# Altium schematic sheet Sheet2.SchDoc(Sheet2)
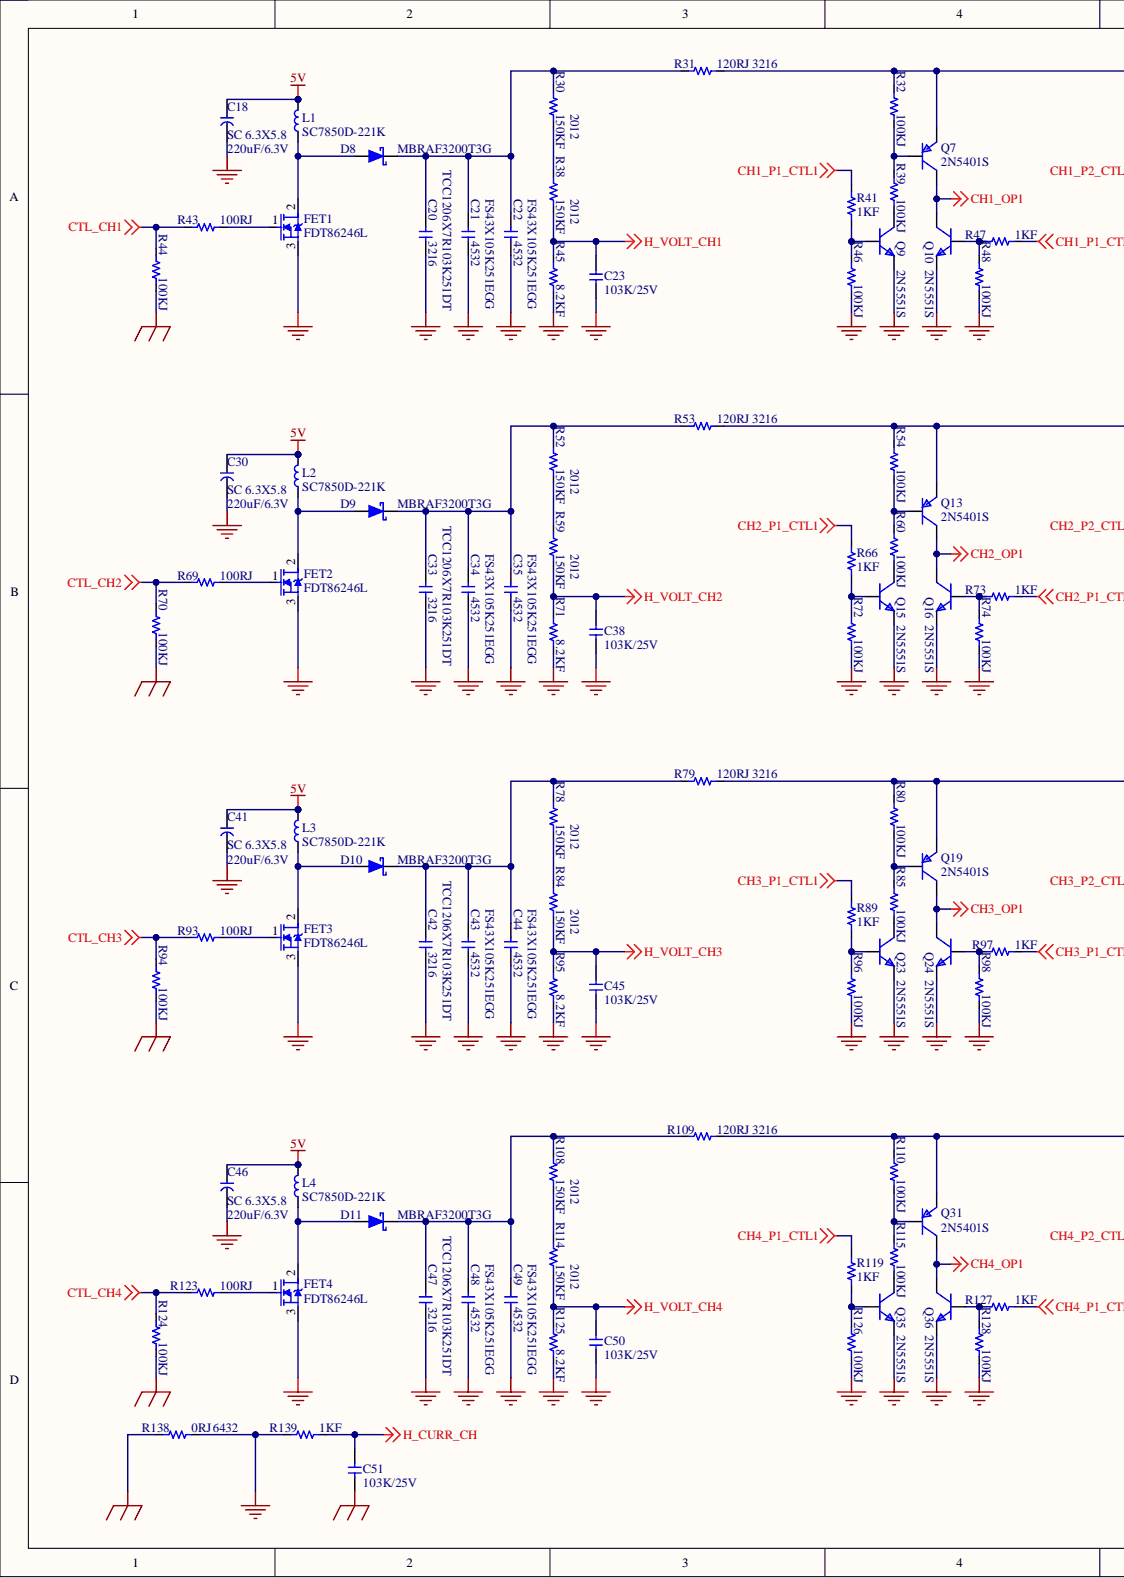
[diagram p0: Sheet2.SchDoc, left]
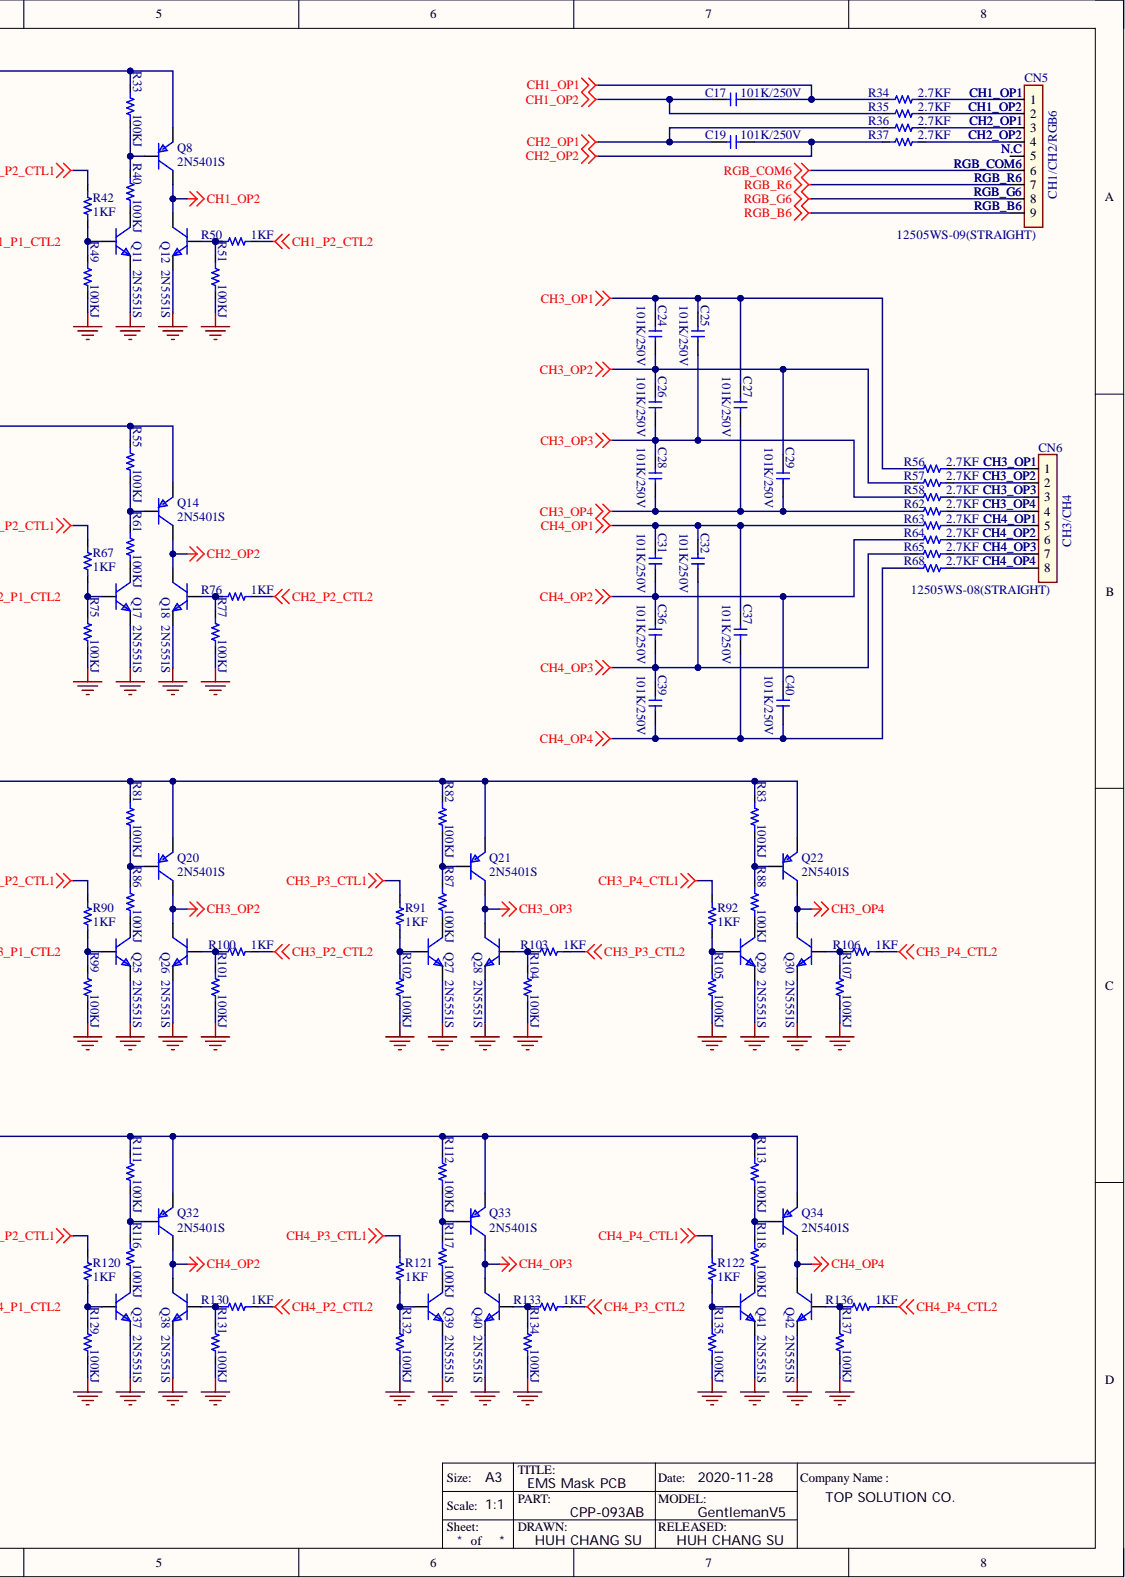
[diagram p1: Sheet2.SchDoc, right]

## Parts
C17  101K/250V  [SMD C 0805]
C18  220uF/6.3V  [SMD SC D(6.3*5.4)]
C19  101K/250V  [SMD C 0805]
C20  TCC1206X7R103K251DT  TYPE: 3216  [SMD C 1206]
C21  FS43X105K251EGG  TYPE: 4532  [SMD C 1812]
C22  FS43X105K251EGG  TYPE: 4532  [SMD C 1812]
C23  103K/25V  [SMD C 0603]
C24  101K/250V  [SMD C 0805]
C25  101K/250V  [SMD C 0805]
C26  101K/250V  [SMD C 0805]
C27  101K/250V  [SMD C 0805]
C28  101K/250V  [SMD C 0805]
C29  101K/250V  [SMD C 0805]
C30  220uF/6.3V  [SMD SC D(6.3*5.4)]
C31  101K/250V  [SMD C 0805]
C32  101K/250V  [SMD C 0805]
C33  TCC1206X7R103K251DT  TYPE: 3216  [SMD C 1206]
C34  FS43X105K251EGG  TYPE: 4532  [SMD C 1812]
C35  FS43X105K251EGG  TYPE: 4532  [SMD C 1812]
C36  101K/250V  [SMD C 0805]
C37  101K/250V  [SMD C 0805]
C38  103K/25V  [SMD C 0603]
C39  101K/250V  [SMD C 0805]
C40  101K/250V  [SMD C 0805]
C41  220uF/6.3V  [SMD SC D(6.3*5.4)]
C42  TCC1206X7R103K251DT  TYPE: 3216  [SMD C 1206]
C43  FS43X105K251EGG  TYPE: 4532  [SMD C 1812]
C44  FS43X105K251EGG  TYPE: 4532  [SMD C 1812]
C45  103K/25V  [SMD C 0603]
C46  220uF/6.3V  [SMD SC D(6.3*5.4)]
C47  TCC1206X7R103K251DT  TYPE: 3216  [SMD C 1206]
C48  FS43X105K251EGG  TYPE: 4532  [SMD C 1812]
C49  FS43X105K251EGG  TYPE: 4532  [SMD C 1812]
C50  103K/25V  [SMD C 0603]
C51  103K/25V  [SMD C 0603]
CN5  12505WS-09(STRAIGHT)  EXPLANATION: CH1/CH2/RGB6  [SMD 12505WS-09_L]
CN6  12505WS-08(STRAIGHT)  EXPLANATION: CH3/CH4  [SMD 12505WS-08_L]
D8  MBRAF3200T3G  [SD SMA]
D9  MBRAF3200T3G  [SD SMA]
D10  MBRAF3200T3G  [SD SMA]
D11  MBRAF3200T3G  [SD SMA]
FET1  FDT86246L  [SOT223_M]
FET2  FDT86246L  [SOT223_M]
FET3  FDT86246L  [SOT223_M]
FET4  FDT86246L  [SOT223_M]
L1  SC7850D-221K  [SMD CD75]
L2  SC7850D-221K  [SMD CD75]
L3  SC7850D-221K  [SMD CD75]
L4  SC7850D-221K  [SMD CD75]
Q7  2N5401S  [SOT23-3N]
Q8  2N5401S  [SOT23-3N]
Q9  2N5551S  [SOT23-3N]
Q10  2N5551S  [SOT23-3N]
Q11  2N5551S  [SOT23-3N]
Q12  2N5551S  [SOT23-3N]
Q13  2N5401S  [SOT23-3N]
Q14  2N5401S  [SOT23-3N]
Q15  2N5551S  [SOT23-3N]
Q16  2N5551S  [SOT23-3N]
Q17  2N5551S  [SOT23-3N]
Q18  2N5551S  [SOT23-3N]
Q19  2N5401S  [SOT23-3N]
Q20  2N5401S  [SOT23-3N]
Q21  2N5401S  [SOT23-3N]
Q22  2N5401S  [SOT23-3N]
Q23  2N5551S  [SOT23-3N]
Q24  2N5551S  [SOT23-3N]
Q25  2N5551S  [SOT23-3N]
Q26  2N5551S  [SOT23-3N]
Q27  2N5551S  [SOT23-3N]
Q28  2N5551S  [SOT23-3N]
Q29  2N5551S  [SOT23-3N]
Q30  2N5551S  [SOT23-3N]
Q31  2N5401S  [SOT23-3N]
Q32  2N5401S  [SOT23-3N]
Q33  2N5401S  [SOT23-3N]
Q34  2N5401S  [SOT23-3N]
Q35  2N5551S  [SOT23-3N]
Q36  2N5551S  [SOT23-3N]
Q37  2N5551S  [SOT23-3N]
Q38  2N5551S  [SOT23-3N]
Q39  2N5551S  [SOT23-3N]
Q40  2N5551S  [SOT23-3N]
Q41  2N5551S  [SOT23-3N]
Q42  2N5551S  [SOT23-3N]
R30  150KF  TYPE: 2012  [SR 0805]
R31  120RJ  TYPE: 3216  [SR 1206]
R32  100KJ  [SR 0603]
R33  100KJ  [SR 0603]
R34  2.7KF  [SR 0603]
R35  2.7KF  [SR 0603]
R36  2.7KF  [SR 0603]
R37  2.7KF  [SR 0603]
R38  150KF  TYPE: 2012  [SR 0805]
R39  100KJ  [SR 0603]
R40  100KJ  [SR 0603]
R41  1KF  [SR 0603]
R42  1KF  [SR 0603]
R43  100RJ  [SR 0603]
R44  100KJ  [SR 0603]
R45  8.2KF  [SR 0603]
R46  100KJ  [SR 0603]
R47  1KF  [SR 0603]
R48  100KJ  [SR 0603]
R49  100KJ  [SR 0603]
R50  1KF  [SR 0603]
R51  100KJ  [SR 0603]
R52  150KF  TYPE: 2012  [SR 0805]
R53  120RJ  TYPE: 3216  [SR 1206]
R54  100KJ  [SR 0603]
R55  100KJ  [SR 0603]
R56  2.7KF  [SR 0603]
R57  2.7KF  [SR 0603]
R58  2.7KF  [SR 0603]
R59  150KF  TYPE: 2012  [SR 0805]
R60  100KJ  [SR 0603]
R61  100KJ  [SR 0603]
R62  2.7KF  [SR 0603]
R63  2.7KF  [SR 0603]
R64  2.7KF  [SR 0603]
R65  2.7KF  [SR 0603]
R66  1KF  [SR 0603]
R67  1KF  [SR 0603]
R68  2.7KF  [SR 0603]
R69  100RJ  [SR 0603]
R70  100KJ  [SR 0603]
R71  8.2KF  [SR 0603]
R72  100KJ  [SR 0603]
R73  1KF  [SR 0603]
R74  100KJ  [SR 0603]
R75  100KJ  [SR 0603]
R76  1KF  [SR 0603]
R77  100KJ  [SR 0603]
R78  150KF  TYPE: 2012  [SR 0805]
R79  120RJ  TYPE: 3216  [SR 1206]
R80  100KJ  [SR 0603]
R81  100KJ  [SR 0603]
R82  100KJ  [SR 0603]
R83  100KJ  [SR 0603]
R84  150KF  TYPE: 2012  [SR 0805]
R85  100KJ  [SR 0603]
R86  100KJ  [SR 0603]
R87  100KJ  [SR 0603]
R88  100KJ  [SR 0603]
R89  1KF  [SR 0603]
R90  1KF  [SR 0603]
R91  1KF  [SR 0603]
R92  1KF  [SR 0603]
R93  100RJ  [SR 0603]
R94  100KJ  [SR 0603]
R95  8.2KF  [SR 0603]
R96  100KJ  [SR 0603]
R97  1KF  [SR 0603]
R98  100KJ  [SR 0603]
R99  100KJ  [SR 0603]
R100  1KF  [SR 0603]
R101  100KJ  [SR 0603]
R102  100KJ  [SR 0603]
R103  1KF  [SR 0603]
R104  100KJ  [SR 0603]
R105  100KJ  [SR 0603]
R106  1KF  [SR 0603]
R107  100KJ  [SR 0603]
R108  150KF  TYPE: 2012  [SR 0805]
R109  120RJ  TYPE: 3216  [SR 1206]
R110  100KJ  [SR 0603]
R111  100KJ  [SR 0603]
R112  100KJ  [SR 0603]
R113  100KJ  [SR 0603]
R114  150KF  TYPE: 2012  [SR 0805]
R115  100KJ  [SR 0603]
R116  100KJ  [SR 0603]
R117  100KJ  [SR 0603]
R118  100KJ  [SR 0603]
R119  1KF  [SR 0603]
R120  1KF  [SR 0603]
R121  1KF  [SR 0603]
R122  1KF  [SR 0603]
R123  100RJ  [SR 0603]
R124  100KJ  [SR 0603]
R125  8.2KF  [SR 0603]
R126  100KJ  [SR 0603]
R127  1KF  [SR 0603]
R128  100KJ  [SR 0603]
R129  100KJ  [SR 0603]
R130  1KF  [SR 0603]
R131  100KJ  [SR 0603]
R132  100KJ  [SR 0603]
R133  1KF  [SR 0603]
R134  100KJ  [SR 0603]
R135  100KJ  [SR 0603]
R136  1KF  [SR 0603]
R137  100KJ  [SR 0603]
R138  0RJ  TYPE: 6432  [SR 2512]
R139  1KF  [SR 0603]

## Nets
5V: C18.1 C30.1 C41.1 C46.1 L1.2 L2.2 L3.2 L4.2
CH1_OP1: C17.2 Q7.3 Q10.3 R34.1
CH1_OP2: C17.1 Q8.3 Q12.3 R35.1
CH1_P1_CTL1: R41.2
CH1_P1_CTL2: R47.2
CH1_P2_CTL1: R42.2
CH1_P2_CTL2: R50.2
CH2_OP1: C19.1 Q13.3 Q16.3 R36.1
CH2_OP2: C19.2 Q14.3 Q18.3 R37.1
CH2_P1_CTL1: R66.2
CH2_P1_CTL2: R73.2
CH2_P2_CTL1: R67.2
CH2_P2_CTL2: R76.2
CH3_OP1: C24.2 C25.2 C27.2 Q19.3 Q24.3 R56.1
CH3_OP2: C24.1 C26.2 C29.2 Q20.3 Q26.3 R57.1
CH3_OP3: C25.1 C26.1 C28.2 Q21.3 Q28.3 R58.1
CH3_OP4: C27.1 C28.1 C29.1 Q22.3 Q30.3 R62.1
CH3_P1_CTL1: R89.2
CH3_P1_CTL2: R97.2
CH3_P2_CTL1: R90.2
CH3_P2_CTL2: R100.2
CH3_P3_CTL1: R91.2
CH3_P3_CTL2: R103.2
CH3_P4_CTL1: R92.2
CH3_P4_CTL2: R106.2
CH4_OP1: C31.2 C32.2 C37.2 Q31.3 Q36.3 R63.1
CH4_OP2: C31.1 C36.2 C40.2 Q32.3 Q38.3 R64.1
CH4_OP3: C32.1 C36.1 C39.2 Q33.3 Q40.3 R65.1
CH4_OP4: C37.1 C39.1 C40.1 Q34.3 Q42.3 R68.1
CH4_P1_CTL1: R119.2
CH4_P1_CTL2: R127.2
CH4_P2_CTL1: R120.2
CH4_P2_CTL2: R130.2
CH4_P3_CTL1: R121.2
CH4_P3_CTL2: R133.2
CH4_P4_CTL1: R122.2
CH4_P4_CTL2: R136.2
CTL_CH1: R43.1 R44.2
CTL_CH2: R69.1 R70.2
CTL_CH3: R93.1 R94.2
CTL_CH4: R123.1 R124.2
GND: C51.1 R44.1 R70.1 R94.1 R124.1 R138.1
H_CURR_CH: C51.2 R139.2
H_GND: C18.2 C20.1 C21.1 C22.1 C23.1 C30.2 C33.1 C34.1 C35.1 C38.1 C41.2 C42.1 C43.1 C44.1 C45.1 C46.2 C47.1 C48.1 C49.1 C50.1 FET1.3 FET2.3 FET3.3 FET4.3 Q9.2 Q10.2 Q11.2 Q12.2 Q15.2 Q16.2 Q17.2 Q18.2 Q23.2 Q24.2 Q25.2 Q26.2 Q27.2 Q28.2 Q29.2 Q30.2 Q35.2 Q36.2 Q37.2 Q38.2 Q39.2 Q40.2 Q41.2 Q42.2 R45.1 R46.1 R48.1 R49.1 R51.1 R71.1 R72.1 R74.1 R75.1 R77.1 R95.1 R96.1 R98.1 R99.1 R101.1 R102.1 R104.1 R105.1 R107.1 R125.1 R126.1 R128.1 R129.1 R131.1 R132.1 R134.1 R135.1 R137.1 R138.2 R139.1
H_VOLT_CH1: C23.2 R38.1 R45.2
H_VOLT_CH2: C38.2 R59.1 R71.2
H_VOLT_CH3: C45.2 R84.1 R95.2
H_VOLT_CH4: C50.2 R114.1 R125.2
RGB_B6: CN5.9
RGB_COM6: CN5.6
RGB_G6: CN5.8
RGB_R6: CN5.7
NetC20_2: C20.2 C21.2 C22.2 D8.2 R30.2 R31.1
NetC33_2: C33.2 C34.2 C35.2 D9.2 R52.2 R53.1
NetC42_2: C42.2 C43.2 C44.2 D10.2 R78.2 R79.1
NetC47_2: C47.2 C48.2 C49.2 D11.2 R108.2 R109.1
NetCN5_1: CN5.1 R34.2
NetCN5_2: CN5.2 R35.2
NetCN5_3: CN5.3 R36.2
NetCN5_4: CN5.4 R37.2
NetCN6_1: CN6.1 R56.2
NetCN6_2: CN6.2 R57.2
NetCN6_3: CN6.3 R58.2
NetCN6_4: CN6.4 R62.2
NetCN6_5: CN6.5 R63.2
NetCN6_6: CN6.6 R64.2
NetCN6_7: CN6.7 R65.2
NetCN6_8: CN6.8 R68.2
NetD8_1: D8.1 FET1.2 L1.1
NetD9_1: D9.1 FET2.2 L2.1
NetD10_1: D10.1 FET3.2 L3.1
NetD11_1: D11.1 FET4.2 L4.1
NetFET1_1: FET1.1 R43.2
NetFET2_1: FET2.1 R69.2
NetFET3_1: FET3.1 R93.2
NetFET4_1: FET4.1 R123.2
NetQ7_1: Q7.1 R32.1 R39.2
NetQ7_2: Q7.2 Q8.2 R31.2 R32.2 R33.2
NetQ8_1: Q8.1 R33.1 R40.2
NetQ9_1: Q9.1 R41.1 R46.2
NetQ9_3: Q9.3 R39.1
NetQ10_1: Q10.1 R47.1 R48.2
NetQ11_1: Q11.1 R42.1 R49.2
NetQ11_3: Q11.3 R40.1
NetQ12_1: Q12.1 R50.1 R51.2
NetQ13_1: Q13.1 R54.1 R60.2
NetQ13_2: Q13.2 Q14.2 R53.2 R54.2 R55.2
NetQ14_1: Q14.1 R55.1 R61.2
NetQ15_1: Q15.1 R66.1 R72.2
NetQ15_3: Q15.3 R60.1
NetQ16_1: Q16.1 R73.1 R74.2
NetQ17_1: Q17.1 R67.1 R75.2
NetQ17_3: Q17.3 R61.1
NetQ18_1: Q18.1 R76.1 R77.2
NetQ19_1: Q19.1 R80.1 R85.2
NetQ19_2: Q19.2 Q20.2 Q21.2 Q22.2 R79.2 R80.2 R81.2 R82.2 R83.2
NetQ20_1: Q20.1 R81.1 R86.2
NetQ21_1: Q21.1 R82.1 R87.2
NetQ22_1: Q22.1 R83.1 R88.2
NetQ23_1: Q23.1 R89.1 R96.2
NetQ23_3: Q23.3 R85.1
NetQ24_1: Q24.1 R97.1 R98.2
NetQ25_1: Q25.1 R90.1 R99.2
NetQ25_3: Q25.3 R86.1
NetQ26_1: Q26.1 R100.1 R101.2
NetQ27_1: Q27.1 R91.1 R102.2
NetQ27_3: Q27.3 R87.1
NetQ28_1: Q28.1 R103.1 R104.2
NetQ29_1: Q29.1 R92.1 R105.2
NetQ29_3: Q29.3 R88.1
NetQ30_1: Q30.1 R106.1 R107.2
NetQ31_1: Q31.1 R110.1 R115.2
NetQ31_2: Q31.2 Q32.2 Q33.2 Q34.2 R109.2 R110.2 R111.2 R112.2 R113.2
NetQ32_1: Q32.1 R111.1 R116.2
NetQ33_1: Q33.1 R112.1 R117.2
NetQ34_1: Q34.1 R113.1 R118.2
NetQ35_1: Q35.1 R119.1 R126.2
NetQ35_3: Q35.3 R115.1
NetQ36_1: Q36.1 R127.1 R128.2
NetQ37_1: Q37.1 R120.1 R129.2
NetQ37_3: Q37.3 R116.1
NetQ38_1: Q38.1 R130.1 R131.2
NetQ39_1: Q39.1 R121.1 R132.2
NetQ39_3: Q39.3 R117.1
NetQ40_1: Q40.1 R133.1 R134.2
NetQ41_1: Q41.1 R122.1 R135.2
NetQ41_3: Q41.3 R118.1
NetQ42_1: Q42.1 R136.1 R137.2
NetR30_1: R30.1 R38.2
NetR52_1: R52.1 R59.2
NetR78_1: R78.1 R84.2
NetR108_1: R108.1 R114.2

Not connected: CN5 5

## Library data of the parts
C17 C19 C24-C29 C31 C32 C36 C37 C39 C40 = C: CHIP CERAMIC CAP; MAKER: WALSIN, SAMSUNG; TYPE: 2012; Component Kind: Standard; COST: 8.8; Library Name: Integrated_Library.IntLib
C18 C30 C41 C46 = C-CM: SMD ELECT CAPACITOR; MAKER: SAMYOUNG; TYPE: SC 6.3X5.8; Component Kind: Standard; COST: 42; Library Name: Integrated_Library.IntLib
C20 C33 C42 C47 = C: CHIP CERAMIC CAP; MAKER: CCTC; Component Kind: Standard; COST: 12.4; Library Name: Integrated_Library.IntLib
C21 C22 C34 C35 C43 C44 C48 C49 = C: CHIP CERAMIC CAP; MAKER: WALSIN; Component Kind: Standard; COST: 97.7; Library Name: Integrated_Library.IntLib
C23 C38 C45 C50 C51 = C: CHIP CERAMIC CAP; MAKER: WALSIN, SAMSUNG; TYPE: 1608; Component Kind: Standard; COST: 5.4; Library Name: Integrated_Library.IntLib
CN5 = CON 9P: SMD WAFER; MAKER: YEONHO ELECTRONICS; Component Kind: Standard; COST: 140; Library Name: Integrated_Library.IntLib; TYPE: SMD-9
CN6 = CON 8P: SMD WAFER; MAKER: YEONHO ELECTRONICS; Component Kind: Standard; COST: 120; Library Name: Integrated_Library.IntLib; TYPE: SMD-8
D8 D9 D11 = SS1200: SMD DIODE SCHOTTKY; MAKER: MDD; TYPE: SMA; Component Kind: Standard; COST: 200; Library Name: Integrated_Library.IntLib
D10 = SS1200: SMD DIODE SCHOTTKY; MAKER: ON SEMICONDUCTOR; TYPE: SMA; Component Kind: Standard; COST: 200; Library Name: Integrated_Library.IntLib
FET1-FET4 = FDT86246L: SMD MOSFET N-CHANNEL; MAKER: FAIRCHILD; Component Kind: Standard; COST: 275; Library Name: Integrated_Library.IntLib; TYPE: SOT223
L1-L4 = SC7850D: SMD INDUCTOR; MAKER: SUHKWANG; TYPE: 7850; Component Kind: Standard; COST: 300; Library Name: Integrated_Library.IntLib
Q7 Q8 Q13 Q14 Q19-Q22 Q31-Q34 = 2N5401S: CHIP TRANSISTOR; MAKER: FIRST SILICON; TYPE: SOT-23; Component Kind: Standard; COST: 21; Library Name: Integrated_Library.IntLib
Q9-Q12 Q15-Q18 Q23-Q30 Q35-Q42 = 2N5551S: CHIP TRANSISTOR; MAKER: FIRST SILICON; TYPE: SOT-23; Component Kind: Standard; COST: 21; Library Name: Integrated_Library.IntLib
R30-R139 = R: CHIP RESISTOR; MAKER: WALSIN, SAMSUNG; Component Kind: Standard; Library Name: Integrated_Library.IntLib
  R30 R38 R52 R59 R78 R84 R108 R114: COST: 1.9
  R31 R53 R79 R109: COST: 4.4
  R32-R37 R39-R51 R54-R58 R60-R77 R80-R83 R85-R107 R110-R113 R115-R137 R139: COST: 1.2; TYPE: 1608
  R138: COST: 25
